# Altium schematic sheet P08_STM32MP1_PWR_GND_MTG.SchDoc(P08_STM32MP1_PWR_GND_MTG)
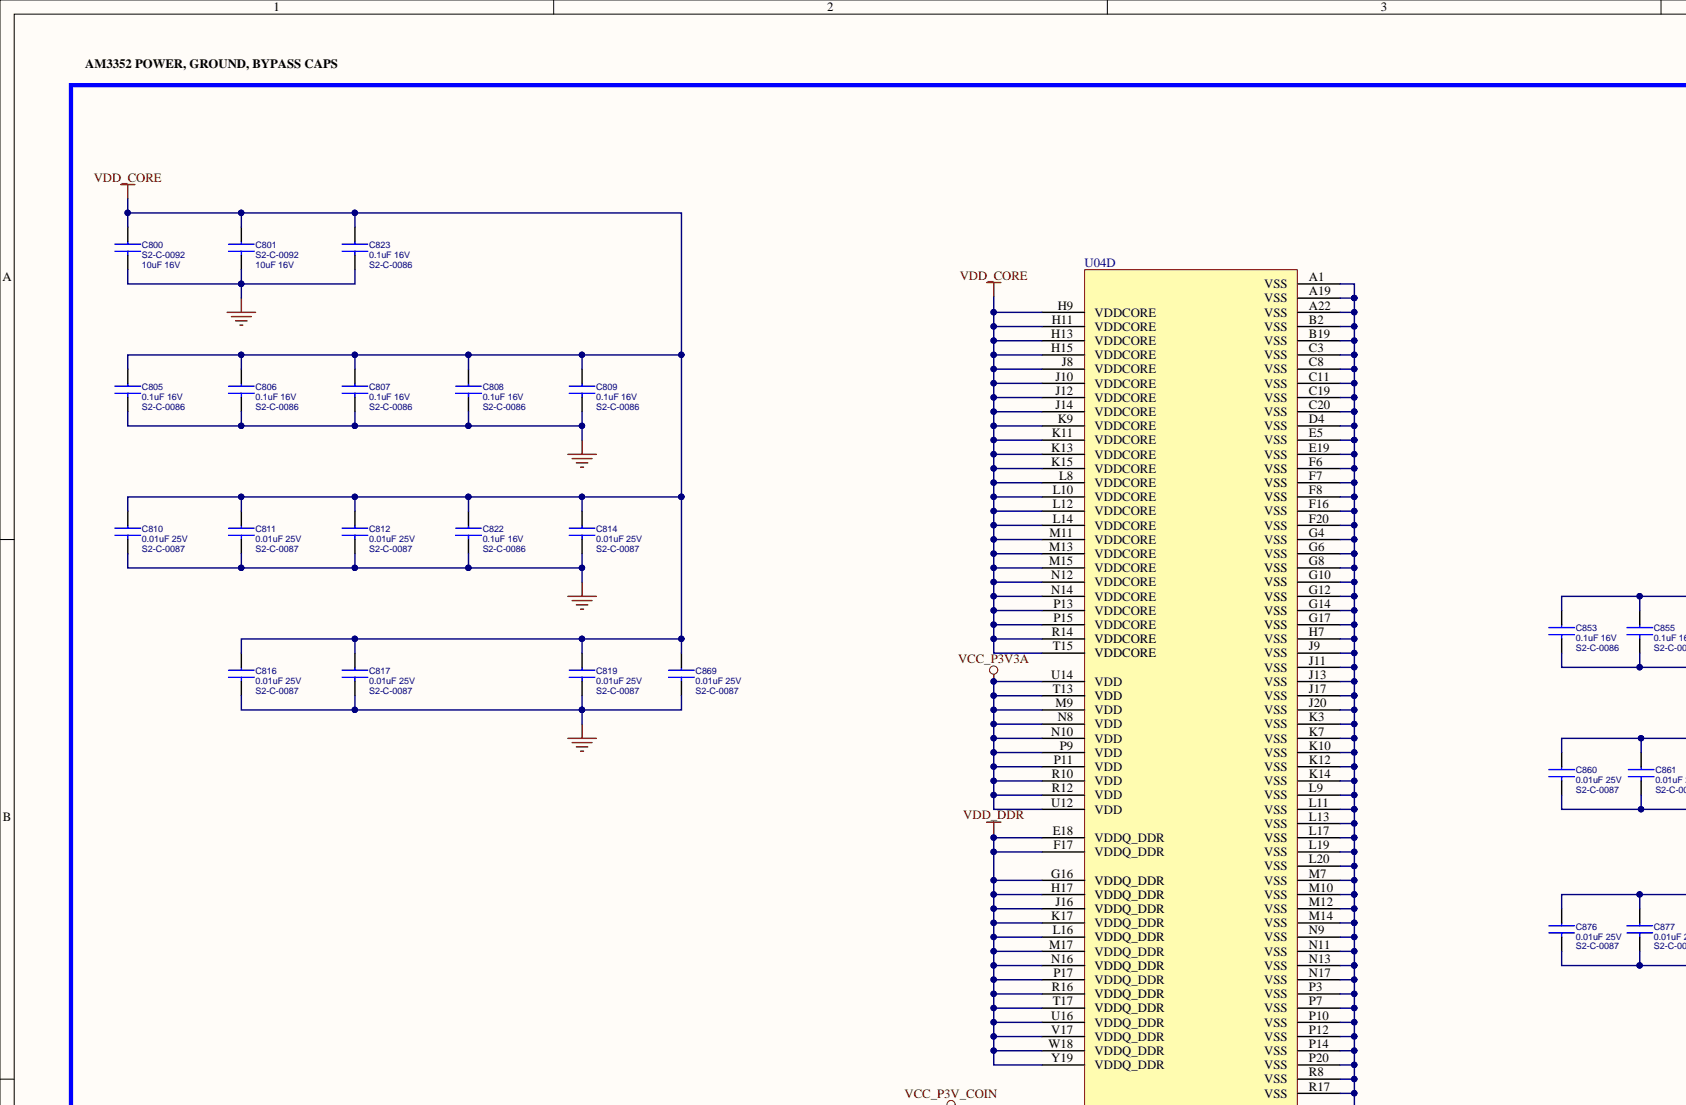
[diagram p0: P08_STM32MP1_PWR_GND_MTG.SchDoc, top left]
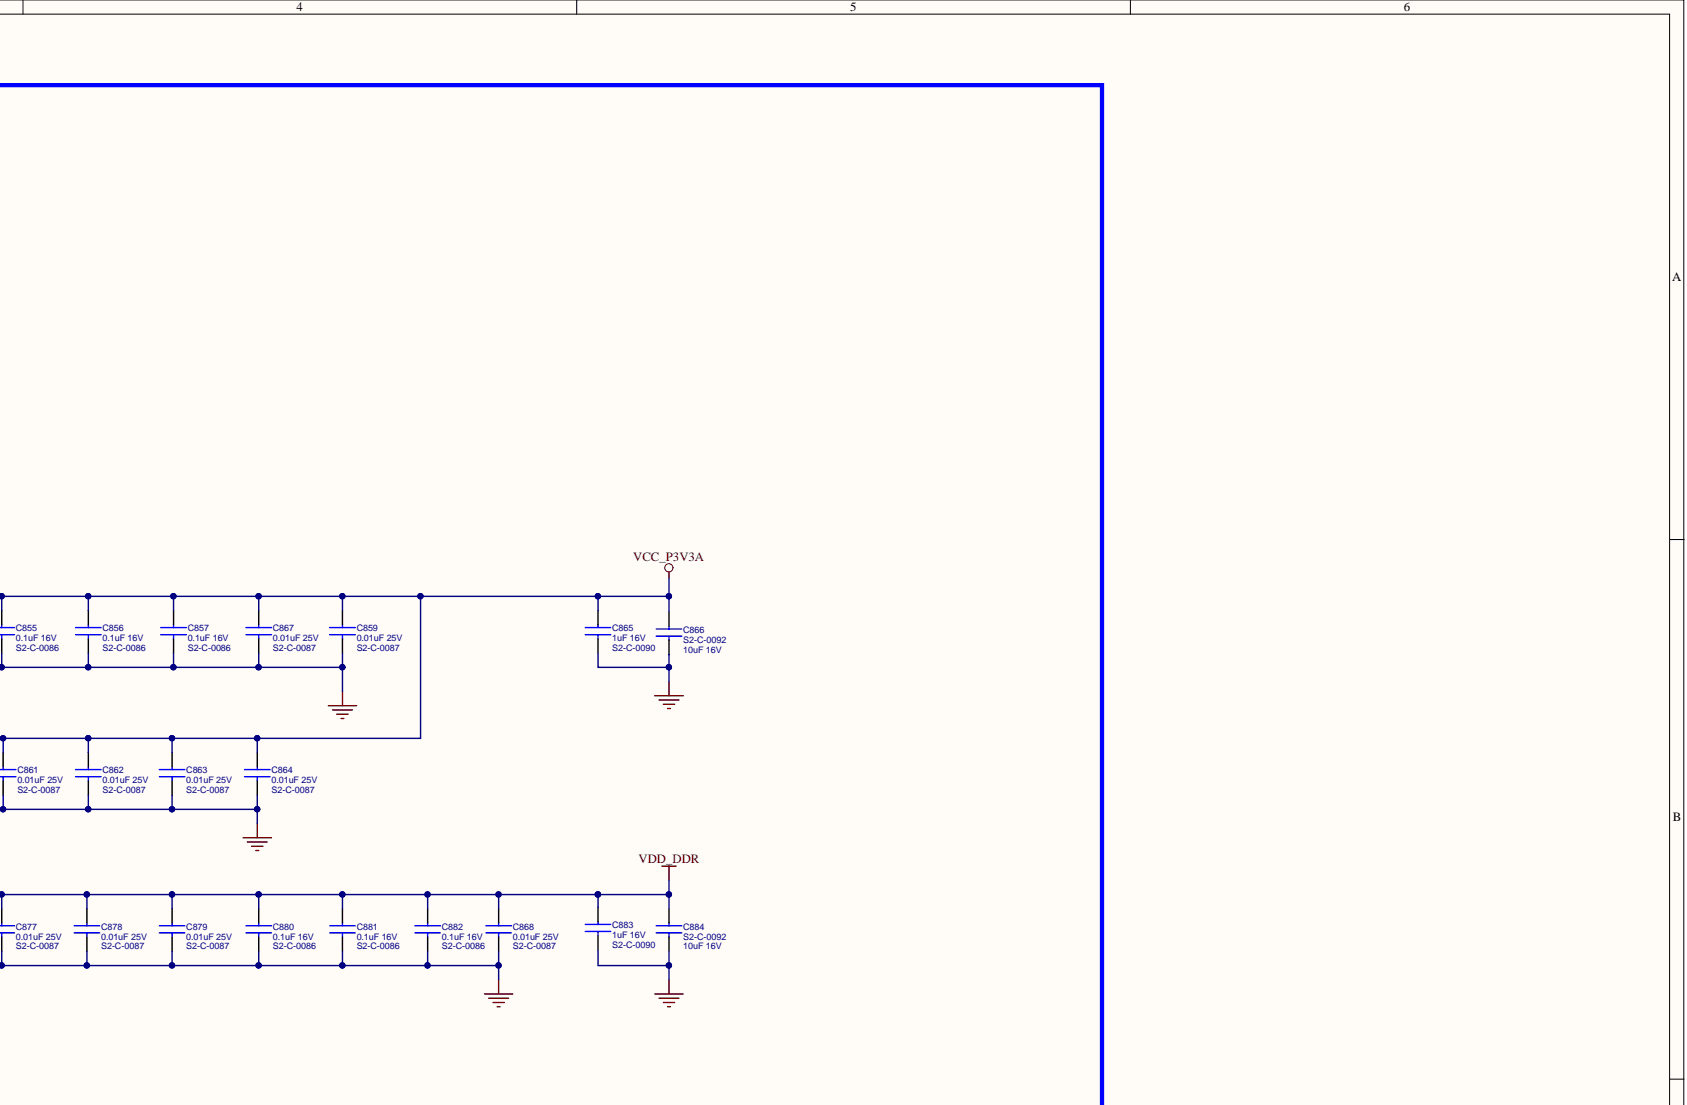
[diagram p1: P08_STM32MP1_PWR_GND_MTG.SchDoc, top right]
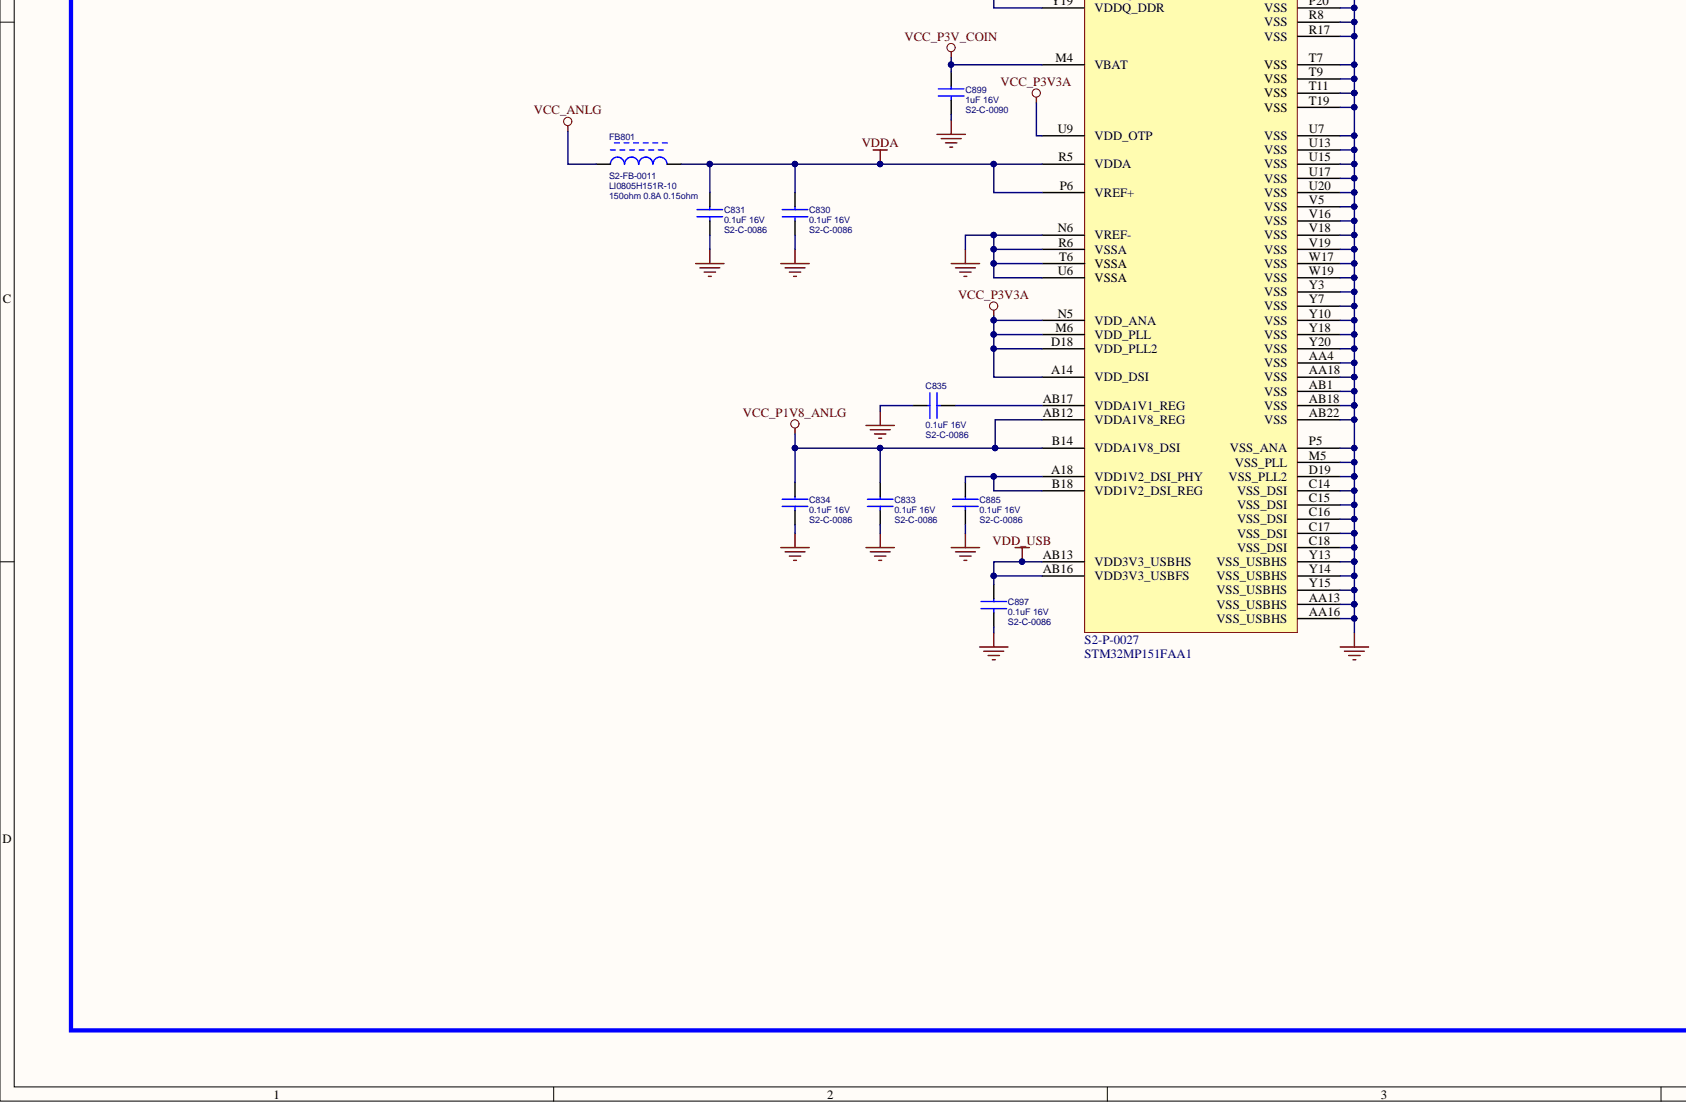
[diagram p2: P08_STM32MP1_PWR_GND_MTG.SchDoc, bottom left]
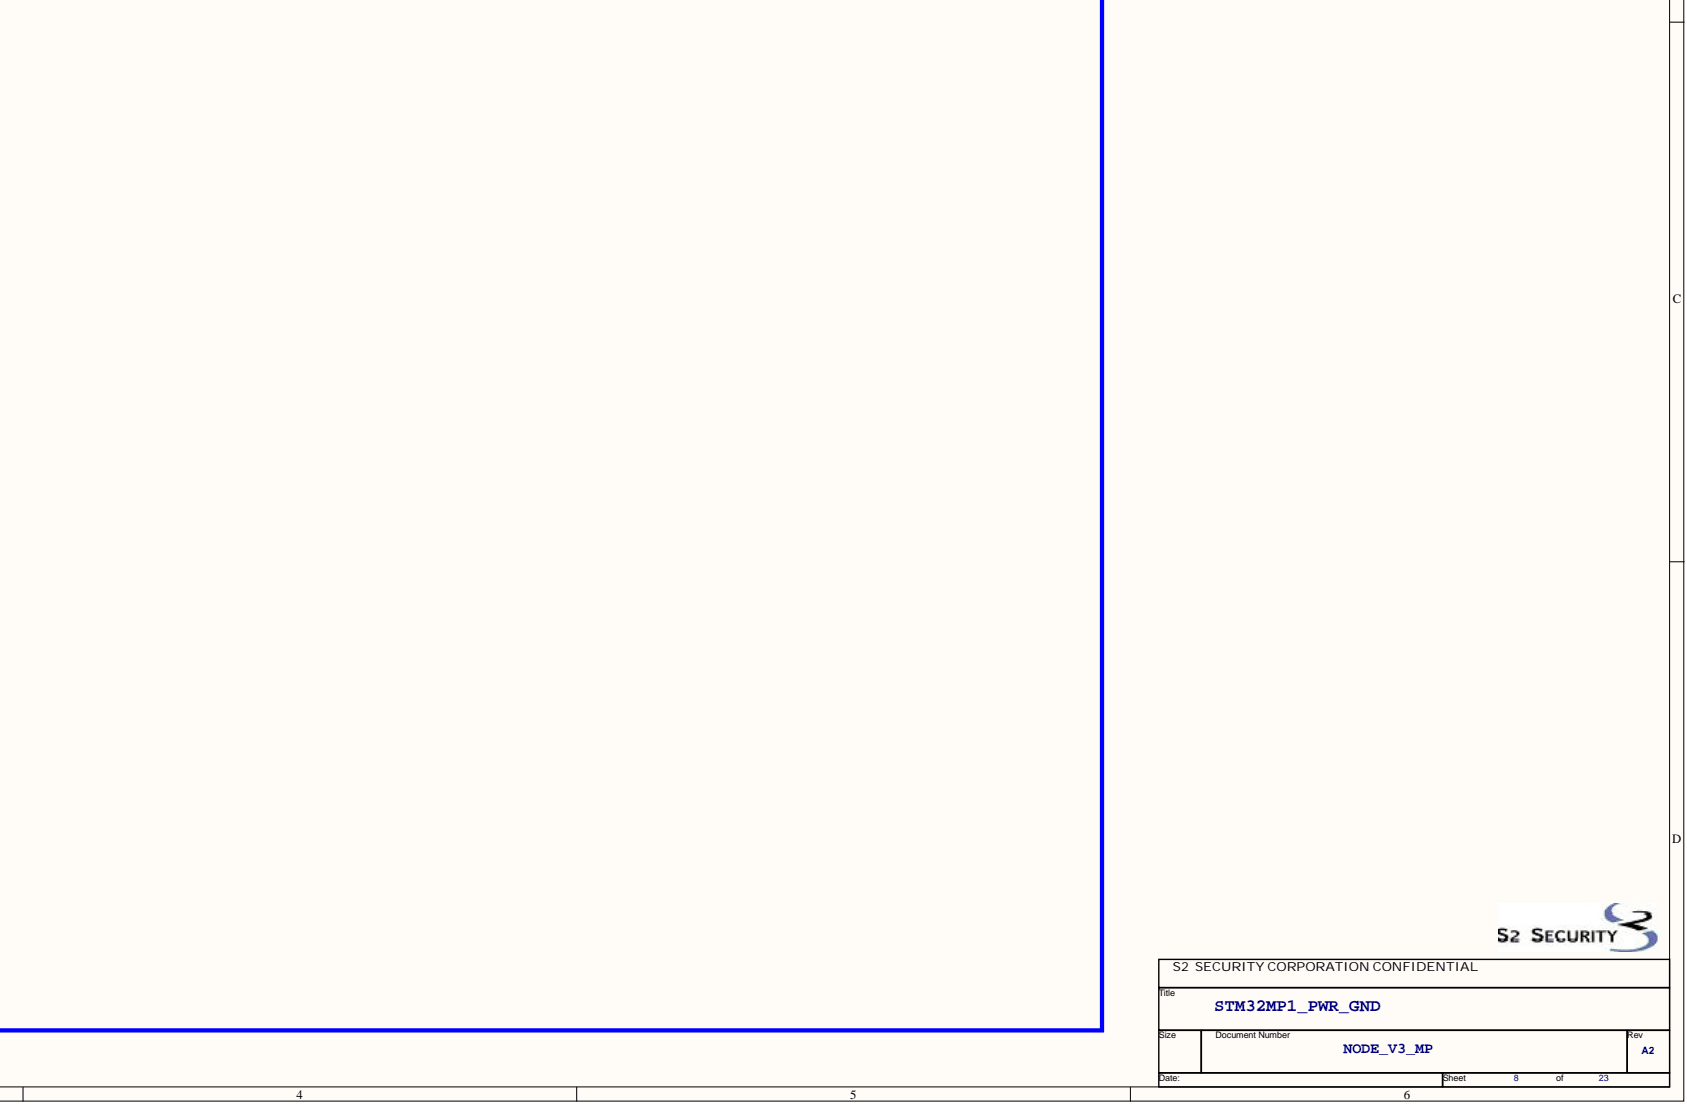
[diagram p3: P08_STM32MP1_PWR_GND_MTG.SchDoc, bottom right]

## Parts
C800  S2_PN: S2-C-0092  DESC: 10uF 16V  [CAPC2012X145N]  (hidden comment: C_10U_16V_0805)
C801  S2_PN: S2-C-0092  DESC: 10uF 16V  [CAPC2012X145N]  (hidden comment: C_10U_16V_0805)
C805  S2_PN: S2-C-0086  DESC: 0.1uF 16V  [CAPC1005X55N]  (hidden comment: C_0U1_16V_0402)
C806  S2_PN: S2-C-0086  DESC: 0.1uF 16V  [CAPC1005X55N]  (hidden comment: C_0U1_16V_0402)
C807  S2_PN: S2-C-0086  DESC: 0.1uF 16V  [CAPC1005X55N]  (hidden comment: C_0U1_16V_0402)
C808  S2_PN: S2-C-0086  DESC: 0.1uF 16V  [CAPC1005X55N]  (hidden comment: C_0U1_16V_0402)
C809  S2_PN: S2-C-0086  DESC: 0.1uF 16V  [CAPC1005X55N]  (hidden comment: C_0U1_16V_0402)
C810  S2_PN: S2-C-0087  DESC: 0.01uF 25V  [CAPC1005X55N]  (hidden comment: C_0U01_25V_0402)
C811  S2_PN: S2-C-0087  DESC: 0.01uF 25V  [CAPC1005X55N]  (hidden comment: C_0U01_25V_0402)
C812  S2_PN: S2-C-0087  DESC: 0.01uF 25V  [CAPC1005X55N]  (hidden comment: C_0U01_25V_0402)
C814  S2_PN: S2-C-0087  DESC: 0.01uF 25V  [CAPC1005X55N]  (hidden comment: C_0U01_25V_0402)
C816  S2_PN: S2-C-0087  DESC: 0.01uF 25V  [CAPC1005X55N]  (hidden comment: C_0U01_25V_0402)
C817  S2_PN: S2-C-0087  DESC: 0.01uF 25V  [CAPC1005X55N]  (hidden comment: C_0U01_25V_0402)
C819  S2_PN: S2-C-0087  DESC: 0.01uF 25V  [CAPC1005X55N]  (hidden comment: C_0U01_25V_0402)
C822  S2_PN: S2-C-0086  DESC: 0.1uF 16V  [CAPC1005X55N]  (hidden comment: C_0U1_16V_0402)
C823  S2_PN: S2-C-0086  DESC: 0.1uF 16V  [CAPC1005X55N]  (hidden comment: C_0U1_16V_0402)
C830  S2_PN: S2-C-0086  DESC: 0.1uF 16V  [CAPC1005X55N]  (hidden comment: C_0U1_16V_0402)
C831  S2_PN: S2-C-0086  DESC: 0.1uF 16V  [CAPC1005X55N]  (hidden comment: C_0U1_16V_0402)
C833  S2_PN: S2-C-0086  DESC: 0.1uF 16V  [CAPC1005X55N]  (hidden comment: C_0U1_16V_0402)
C834  S2_PN: S2-C-0086  DESC: 0.1uF 16V  [CAPC1005X55N]  (hidden comment: C_0U1_16V_0402)
C835  S2_PN: S2-C-0086  DESC: 0.1uF 16V  [CAPC1005X55N]  (hidden comment: C_0U1_16V_0402)
C853  S2_PN: S2-C-0086  DESC: 0.1uF 16V  [CAPC1005X55N]  (hidden comment: C_0U1_16V_0402)
C855  S2_PN: S2-C-0086  DESC: 0.1uF 16V  [CAPC1005X55N]  (hidden comment: C_0U1_16V_0402)
C856  S2_PN: S2-C-0086  DESC: 0.1uF 16V  [CAPC1005X55N]  (hidden comment: C_0U1_16V_0402)
C857  S2_PN: S2-C-0086  DESC: 0.1uF 16V  [CAPC1005X55N]  (hidden comment: C_0U1_16V_0402)
C859  S2_PN: S2-C-0087  DESC: 0.01uF 25V  [CAPC1005X55N]  (hidden comment: C_0U01_25V_0402)
C860  S2_PN: S2-C-0087  [CAPC1005X55N]  (hidden comment: C_0U01_25V_0402)
C861  S2_PN: S2-C-0087  DESC: 0.01uF 25V  [CAPC1005X55N]  (hidden comment: C_0U01_25V_0402)
C862  S2_PN: S2-C-0087  DESC: 0.01uF 25V  [CAPC1005X55N]  (hidden comment: C_0U01_25V_0402)
C863  S2_PN: S2-C-0087  DESC: 0.01uF 25V  [CAPC1005X55N]  (hidden comment: C_0U01_25V_0402)
C864  S2_PN: S2-C-0087  DESC: 0.01uF 25V  [CAPC1005X55N]  (hidden comment: C_0U01_25V_0402)
C865  S2_PN: S2-C-0090  DESC: 1uF 16V  [CAPC1005X55N]  (hidden comment: C_1U_16V_0402)
C866  S2_PN: S2-C-0092  DESC: 10uF 16V  [CAPC2012X145N]  (hidden comment: C_10U_16V_0805)
C867  S2_PN: S2-C-0087  DESC: 0.01uF 25V  [CAPC1005X55N]  (hidden comment: C_0U01_25V_0402)
C868  S2_PN: S2-C-0087  DESC: 0.01uF 25V  [CAPC1005X55N]  (hidden comment: C_0U01_25V_0402)
C869  S2_PN: S2-C-0087  DESC: 0.01uF 25V  [CAPC1005X55N]  (hidden comment: C_0U01_25V_0402)
C876  S2_PN: S2-C-0087  [CAPC1005X55N]  (hidden comment: C_0U01_25V_0402)
C877  S2_PN: S2-C-0087  DESC: 0.01uF 25V  [CAPC1005X55N]  (hidden comment: C_0U01_25V_0402)
C878  S2_PN: S2-C-0087  DESC: 0.01uF 25V  [CAPC1005X55N]  (hidden comment: C_0U01_25V_0402)
C879  S2_PN: S2-C-0087  DESC: 0.01uF 25V  [CAPC1005X55N]  (hidden comment: C_0U01_25V_0402)
C880  S2_PN: S2-C-0086  DESC: 0.1uF 16V  [CAPC1005X55N]  (hidden comment: C_0U1_16V_0402)
C881  S2_PN: S2-C-0086  DESC: 0.1uF 16V  [CAPC1005X55N]  (hidden comment: C_0U1_16V_0402)
C882  S2_PN: S2-C-0086  DESC: 0.1uF 16V  [CAPC1005X55N]  (hidden comment: C_0U1_16V_0402)
C883  S2_PN: S2-C-0090  DESC: 1uF 16V  [CAPC1005X55N]  (hidden comment: C_1U_16V_0402)
C884  S2_PN: S2-C-0092  DESC: 10uF 16V  [CAPC2012X145N]  (hidden comment: C_10U_16V_0805)
C885  S2_PN: S2-C-0086  DESC: 0.1uF 16V  [CAPC1005X55N]  (hidden comment: C_0U1_16V_0402)
C897  S2_PN: S2-C-0086  [CAPC1005X55N]  (hidden comment: C_0U1_16V_0402)
C899  S2_PN: S2-C-0090  DESC: 1uF 16V  [CAPC1005X55N]  (hidden comment: C_1U_16V_0402)
FB801  S2_PN: S2-FB-0011  DESC: LI0805H151R-10  [FB0805]  (hidden comment: FB_0A8_150OHM_0805_DC_150mOHM)
U04  S2_PN: S2-P-0027  DESC: STM32MP151FAA1  [ICC_LFBGA448_18X18_STM32MP157BAA3_P080]  (hidden comment: U_STM32MP15xxAAx)  (part D)

## Nets
GND: C800.2 C801.2 C805.2 C806.2 C807.2 C808.2 C809.2 C810.2 C811.2 C812.2 C814.2 C816.2 C817.2 C819.2 C822.2 C823.2 C830.2 C831.2 C833.2 C834.2 C835.1 C853.2 C855.2 C856.2 C857.2 C859.2 C860.2 C861.2 C862.2 C863.2 C864.2 C865.2 C866.2 C867.2 C868.2 C869.2 C876.2 C877.2 C878.2 C879.2 C880.2 C881.2 C882.2 C883.2 C884.2 C885.2 C897.2 C899.2 U04.A1(VSS) U04.A19(VSS) U04.A22(VSS) U04.AA4(VSS) U04.AA13(VSS_USBHS) U04.AA16(VSS_USBHS) U04.AA18(VSS) U04.AB1(VSS) U04.AB18(VSS) U04.AB22(VSS) U04.B2(VSS) U04.B19(VSS) U04.C3(VSS) U04.C8(VSS) U04.C11(VSS) U04.C14(VSS_DSI) U04.C15(VSS_DSI) U04.C16(VSS_DSI) U04.C17(VSS_DSI) U04.C18(VSS_DSI) U04.C19(VSS) U04.C20(VSS) U04.D4(VSS) U04.D19(VSS_PLL2) U04.E5(VSS) U04.E19(VSS) U04.F6(VSS) U04.F7(VSS) U04.F8(VSS) U04.F16(VSS) U04.F20(VSS) U04.G4(VSS) U04.G6(VSS) U04.G8(VSS) U04.G10(VSS) U04.G12(VSS) U04.G14(VSS) U04.G17(VSS) U04.H7(VSS) U04.J9(VSS) U04.J11(VSS) U04.J13(VSS) U04.J17(VSS) U04.J20(VSS) U04.K3(VSS) U04.K7(VSS) U04.K10(VSS) U04.K12(VSS) U04.K14(VSS) U04.L9(VSS) U04.L11(VSS) U04.L13(VSS) U04.L17(VSS) U04.L19(VSS) U04.L20(VSS) U04.M5(VSS_PLL) U04.M7(VSS) U04.M10(VSS) U04.M12(VSS) U04.M14(VSS) U04.N6(VREF-) U04.N9(VSS) U04.N11(VSS) U04.N13(VSS) U04.N17(VSS) U04.P3(VSS) U04.P5(VSS_ANA) U04.P7(VSS) U04.P10(VSS) U04.P12(VSS) U04.P14(VSS) U04.P20(VSS) U04.R6(VSSA) U04.R8(VSS) U04.R17(VSS) U04.T6(VSSA) U04.T7(VSS) U04.T9(VSS) U04.T11(VSS) U04.T19(VSS) U04.U6(VSSA) U04.U7(VSS) U04.U13(VSS) U04.U15(VSS) U04.U17(VSS) U04.U20(VSS) U04.V5(VSS) U04.V16(VSS) U04.V18(VSS) U04.V19(VSS) U04.W17(VSS) U04.W19(VSS) U04.Y3(VSS) U04.Y7(VSS) U04.Y10(VSS) U04.Y13(VSS_USBHS) U04.Y14(VSS_USBHS) U04.Y15(VSS_USBHS) U04.Y18(VSS) U04.Y20(VSS)
VCC_ANLG: FB801.1
VCC_P1V8_ANLG: C833.1 C834.1 U04.AB12(VDDA1V8_REG) U04.B14(VDDA1V8_DSI)
VCC_P3V3A: C853.1 C855.1 C856.1 C857.1 C859.1 C860.1 C861.1 C862.1 C863.1 C864.1 C865.1 C866.1 C867.1 U04.A14(VDD_DSI) U04.D18(VDD_PLL2) U04.M6(VDD_PLL) U04.M9(VDD) U04.N5(VDD_ANA) U04.N8(VDD) U04.N10(VDD) U04.P9(VDD) U04.P11(VDD) U04.R10(VDD) U04.R12(VDD) U04.T13(VDD) U04.U9(VDD_OTP) U04.U12(VDD) U04.U14(VDD)
VCC_P3V_COIN: C899.1 U04.M4(VBAT)
VDD_CORE: C800.1 C801.1 C805.1 C806.1 C807.1 C808.1 C809.1 C810.1 C811.1 C812.1 C814.1 C816.1 C817.1 C819.1 C822.1 C823.1 C869.1 U04.H9(VDDCORE) U04.H11(VDDCORE) U04.H13(VDDCORE) U04.H15(VDDCORE) U04.J8(VDDCORE) U04.J10(VDDCORE) U04.J12(VDDCORE) U04.J14(VDDCORE) U04.K9(VDDCORE) U04.K11(VDDCORE) U04.K13(VDDCORE) U04.K15(VDDCORE) U04.L8(VDDCORE) U04.L10(VDDCORE) U04.L12(VDDCORE) U04.L14(VDDCORE) U04.M11(VDDCORE) U04.M13(VDDCORE) U04.M15(VDDCORE) U04.N12(VDDCORE) U04.N14(VDDCORE) U04.P13(VDDCORE) U04.P15(VDDCORE) U04.R14(VDDCORE) U04.T15(VDDCORE)
VDD_DDR: C868.1 C876.1 C877.1 C878.1 C879.1 C880.1 C881.1 C882.1 C883.1 C884.1 U04.E18(VDDQ_DDR) U04.F17(VDDQ_DDR) U04.G16(VDDQ_DDR) U04.H17(VDDQ_DDR) U04.J16(VDDQ_DDR) U04.K17(VDDQ_DDR) U04.L16(VDDQ_DDR) U04.M17(VDDQ_DDR) U04.N16(VDDQ_DDR) U04.P17(VDDQ_DDR) U04.R16(VDDQ_DDR) U04.T17(VDDQ_DDR) U04.U16(VDDQ_DDR) U04.V17(VDDQ_DDR) U04.W18(VDDQ_DDR) U04.Y19(VDDQ_DDR)
VDD_USB: C897.1 U04.AB13(VDD3V3_USBHS) U04.AB16(VDD3V3_USBFS)
VDDA: C830.1 C831.1 FB801.2 U04.P6(VREF+) U04.R5(VDDA)
NetC835_2: C835.2 U04.AB17(VDDA1V1_REG)
NetC885_1: C885.1 U04.A18(VDD1V2_DSI_PHY) U04.B18(VDD1V2_DSI_REG)

## Library data of the parts
C800 C801 C866 C884 = C_10U_16V_0805: PKG: CC0805IPC; ATTR: X5R 10%; Library Name: NODE_V2_M1.SCHLIB; Component Kind: Standard
C805-C809 C822 C823 C830 C831 C833-C835 C853 C855-C857 C880-C882 C885 = C_0U1_16V_0402: PKG: CC0402IPC; ATTR: X7R 10%; Library Name: NODE_V2_M1.SCHLIB; Component Kind: Standard
C810-C812 C814 C816 C817 C819 C859 C861-C864 C867-C869 C877-C879 = C_0U01_25V_0402: PKG: CC0402IPC; ATTR: X7R 10%; Library Name: NODE_V2_M1.SCHLIB; Component Kind: Standard
C860 C876 = C_0U01_25V_0402: PKG: CC0402IPC; DESC: 0.01uF 25V; ATTR: X7R 10%; Library Name: NODE_V2_M1.SCHLIB; Component Kind: Standard
C865 C883 C899 = C_1U_16V_0402: PKG: CC0402IPC; ATTR: X5R 10%; Library Name: NODE_V2_M1.SCHLIB; Component Kind: Standard
C897 = C_0U1_16V_0402: PKG: CC0402IPC; DESC: 0.1uF 16V; ATTR: X7R 10%; Library Name: NODE_V2_M1.SCHLIB; Component Kind: Standard
FB801 = FB_0A8_150OHM_0805_DC_150mOHM: PKG: CC0805IPC; ATTR2: 150ohm 0.8A 0.15ohm; ATTR: FERRITE 800MA 150 OHM 0805, 0.15 OHM DC; Library Name: NODE_V2_M1.SCHLIB; Component Kind: Standard
U04 = (no symbol name): MPU LFBGA18x18; ATTR: ARM Cortex-A7 Microprocessor IC STM32MP1 1 Core, 32-Bit 209MHz, 800MHz 448-LFBGA (18x18); PKG: LFBGA18x18; Library Name: NODE_V2_M1.SCHLIB; Component Kind: Standard
